# Altium schematic sheet 7seg.SchDoc
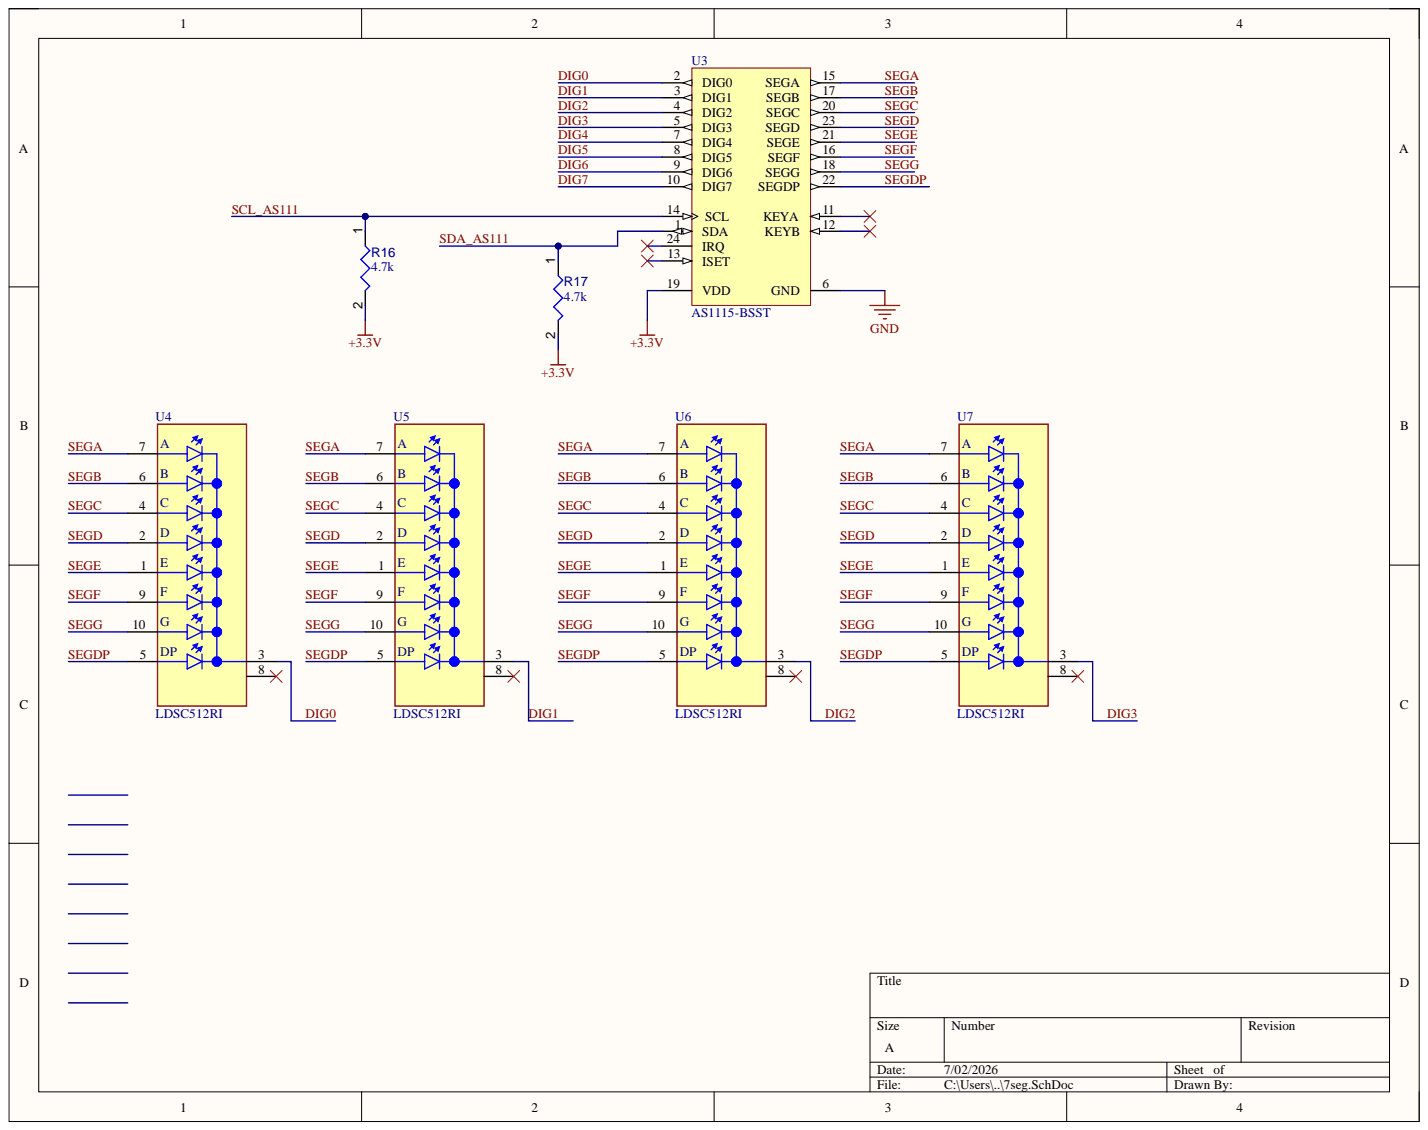
[diagram p0: 7seg.SchDoc]

## Parts
R16  value 4.7k  [RESC0402(1005)_L]  (hidden comment: Resistor 4K7  +/-1 % 0402 63 mW)
R17  value 4.7k  [RESC0402(1005)_L]  (hidden comment: Resistor 4K7  +/-1 % 0402 63 mW)
U3  AS1115-BSST  [AMS-QSOP-24-8645X3900DE_N]
U4  LDSC512RI  [LED_LDSC512RI]
U5  LDSC512RI  [LED_LDSC512RI]
U6  LDSC512RI  [LED_LDSC512RI]
U7  LDSC512RI  [LED_LDSC512RI]

## Nets
+3.3V: R16.2 R17.2 U3.19(VDD)
GND: U3.6(GND)
DIG0: U3.2(DIG0) U4.3
DIG1: U3.3(DIG1) U5.3
DIG2: U3.4(DIG2) U6.3
DIG3: U3.5(DIG3) U7.3
DIG4: U3.7(DIG4)
DIG5: U3.8(DIG5)
DIG6: U3.9(DIG6)
DIG7: U3.10(DIG7)
SCL_AS111: R16.1 U3.14(SCL)
SDA_AS111: R17.1 U3.1(SDA)
SEGA: U3.15(SEGA) U4.7 U5.7 U6.7 U7.7
SEGB: U3.17(SEGB) U4.6 U5.6 U6.6 U7.6
SEGC: U3.20(SEGC) U4.4 U5.4 U6.4 U7.4
SEGD: U3.23(SEGD) U4.2 U5.2 U6.2 U7.2
SEGDP: U3.22(SEGDP) U4.5 U5.5 U6.5 U7.5
SEGE: U3.21(SEGE) U4.1 U5.1 U6.1 U7.1
SEGF: U3.16(SEGF) U4.9 U5.9 U6.9 U7.9
SEGG: U3.18(SEGG) U4.10 U5.10 U6.10 U7.10

Not connected: U3 11(KEYA) 12(KEYB) 13(ISET) 24(IRQ); U4 8; U5 8; U6 8; U7 8

## Library data of the parts
R16 R17 = CMP-009-00158-3: Chip Resistor, 4,7 kOhms, +/-1 %, 63 mW, -55 to 155 degC, 0402; Power: 63mW; Tolerance: 1%; Case/Package: 0402; Component Kind: Standard; Max Operating Temperature: 155°C; Min Operating Temperature: -55°C; Pins: 2; Library Name: Talha Khan
U3 = CMP-001-0000-1: 8Digits Tape & Reel (TR) 8x (7Segment) 64LED SERIAL display driver 350muA 5.5V 8.66mm -40C~85C; Component Kind: Standard; Library Name: Talha Khan
U4-U7 = CMP-009-000000-1: .56IN. 7 SEGMENT SINGLE DISPLAY, 565NM GREEN, GRAY FACE, WHITE SEGMENTS, COMMON; MF: Lumex; MP: LDS-C512RI; Check_prices: https://www.snapeda.com/parts/LDS-C512RI/Lumex/view-part/?ref=eda; Component Kind: Standard; Component Type: Standard; Design Item ID: LDSC512RI; Package: None; Pin Count: 10; SnapEDA_Link: https://www.snapeda.com/parts/LDS-C512RI/Lumex/view-part/?ref=snap; Source: LDSC512RI.SchLib; Library Name: Talha Khan
